# Altium schematic sheet Buck_Boost Controller.SchDoc("Buck_Boost Controller")
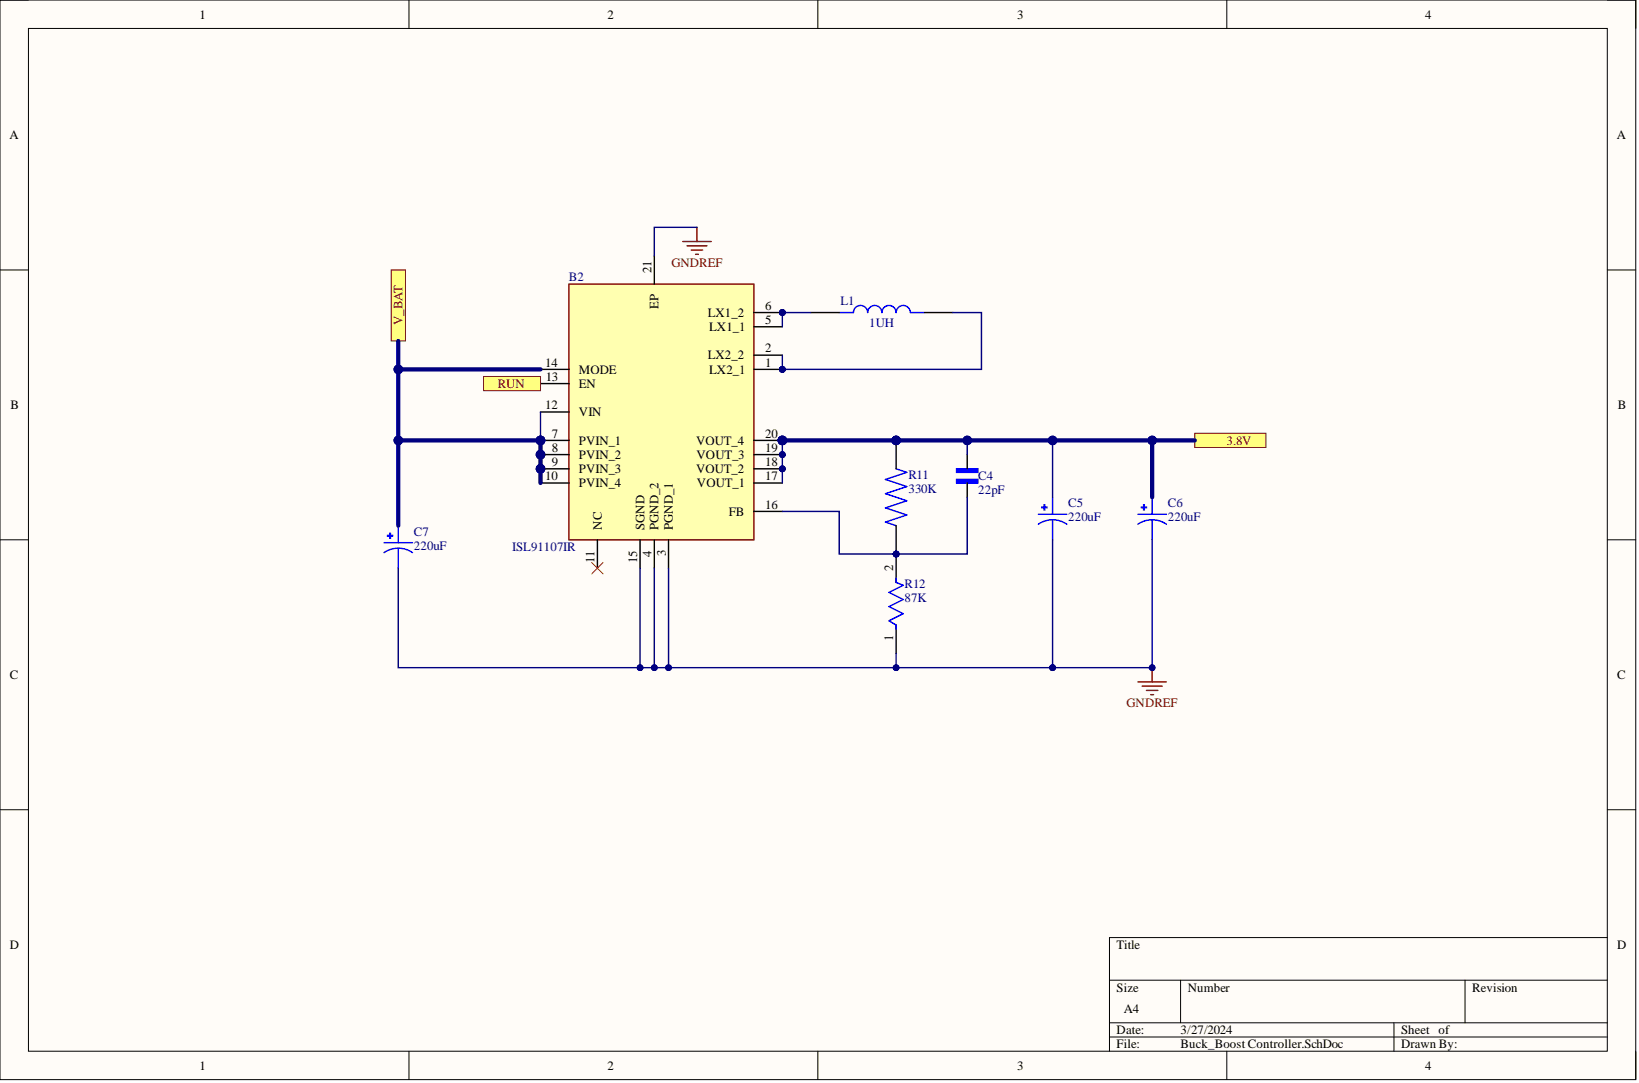
[diagram p0: Buck_Boost Controller.SchDoc]

## Parts
B2  ISL91107IR
C4  22pF
C5  220uF
C6  220uF
C7  220uF
L1  1UH
R11  330K
R12  87K

## Ports
3.8V, RUN, V_BAT

## Nets
GNDREF: B2.3(PGND_1) B2.4(PGND_2) B2.15(SGND) B2.21(EP) C5.2 C6.2 C7.2 R12.1
3.8V: B2.17(VOUT_1) B2.18(VOUT_2) B2.19(VOUT_3) B2.20(VOUT_4) C4.2 C5.1 C6.1 R11.2 ; port 3.8V
RUN: B2.13(EN) ; port RUN
V_BAT: B2.7(PVIN_1) B2.8(PVIN_2) B2.9(PVIN_3) B2.10(PVIN_4) B2.12(VIN) B2.14(MODE) C7.1 ; port V_BAT
NetB2_1: B2.1(LX2_1) B2.2(LX2_2) L1.2
NetB2_5: B2.5(LX1_1) B2.6(LX1_2) L1.1
NetB2_16: B2.16(FB) C4.1 R11.1 R12.2

Not connected: B2 11(NC)

## Library data of the parts
B2 = ISL91107IRTNZ-T7A: The ISL91107IR is a highly-integrated buck-boost switching regulator that accepts input voltages either above or below the regulated output voltage. Unlike other buck-boost regulators, this regulator automatically transitions between operating modes without significant output disturbance.This device is capable of delivering up to 2A of output current (PVIN = 2.8V, VOUT = 3.3V) and provides excellent efficiency due to its fully synchronous 4-switch architecture. No-load quiescent current of only 45A also op; Manufacturer_Name: Renesas Electronics; Geometry.Height: 0.8mm; Arrow Part Number: ISL91107IRTNZ-T7A; Arrow Price/Stock: https://www.arrow.com/en/products/isl91107irtnz-t7a/integrated-device-technology?region=nac; Component Kind: Standard; Datasheet Link: https://www.renesas.com/en-us/www/doc/datasheet/isl91107ir.pdf; Library Name: ISL91107IRTNZ-T7A.SchLib; Manufacturer_Part_Number: ISL91107IRTNZ-T7A; Mouser Part Number: 968-ISL91107IRTNZT7A; Mouser Price/Stock: https://www.mouser.co.uk/ProductDetail/Renesas-Intersil/ISL91107IRTNZ-T7A?qs=DXptKE%252B5xICfvCrqtryiaQ%3D%3D
C4 = 06035C220JAT2A: CAP CER 22PF 50V X7R 0603; MF: {'manufacturer': u'AVX'}; Availability: Unavailable; Component Kind: Standard; MP: 06035C220JAT2A; Package: None; Price: None
C5-C7 = TAJC227K006RNJ: 47ÂµF Molded Tantalum Capacitors 16V 2312 (6032 Metric) 500mOhm; MF: {'manufacturer': u'KYOCERA AVX'}; Availability: Unavailable; Component Kind: Standard; MP: TAJC227K006RNJ; Package: None; Price: None
L1 = TFM322512ALVA1R0MTAA: FIXED IND 1UH 4A 37 MOHM SMD; MF: {'manufacturer': u'TDK Corporation'}; Availability: Unavailable; Component Kind: Standard; MP: TFM322512ALVA1R0MTAA; Package: None; Price: None
R11 = RC0603FR-07330KL: THICK FILM RESISTOR, 330KOHM, 100mW, 1%; MF: {'manufacturer': u'Yageo'}; Availability: Unavailable; Component Kind: Standard; MP: RC0603FR-07330KL; Package: None; Price: None
R12 = CMP-07231-004348-1: Thick Film Chip Resistors 0805 87K; Max Power Dissipation: 125mW; Resistance: 0mR; Temperature Coefficient: 500ppm/°C; Tolerance: 5%; Voltage Rating: 150V; Case Code (Imperial): 0805; Case Code (Metric): 2012; Case/Package: 0805; Component Kind: Standard; Composition: Thick Film; Contact Plating: Tin; Depth: 1.25mm; Height: 0.5mm; Length: 2mm; Library Name: res0805.SchLib; Max Operating Temperature: 155°C; Military Standard: Not; Min Operating Temperature: -55°C; Mount: Surface Mount; Number of Pins: 2; Package Quantity: 5000; Packaging: Tape and Reel; Power Rating: 125mW; Radiation Hardening: No; REACH SVHC: No SVHC; Termination: SMD/SMT; Thickness: 508um; Voltage Rating (DC): 150V; Width: 1.25mm
